# Altium schematic sheet MCU.SchDoc
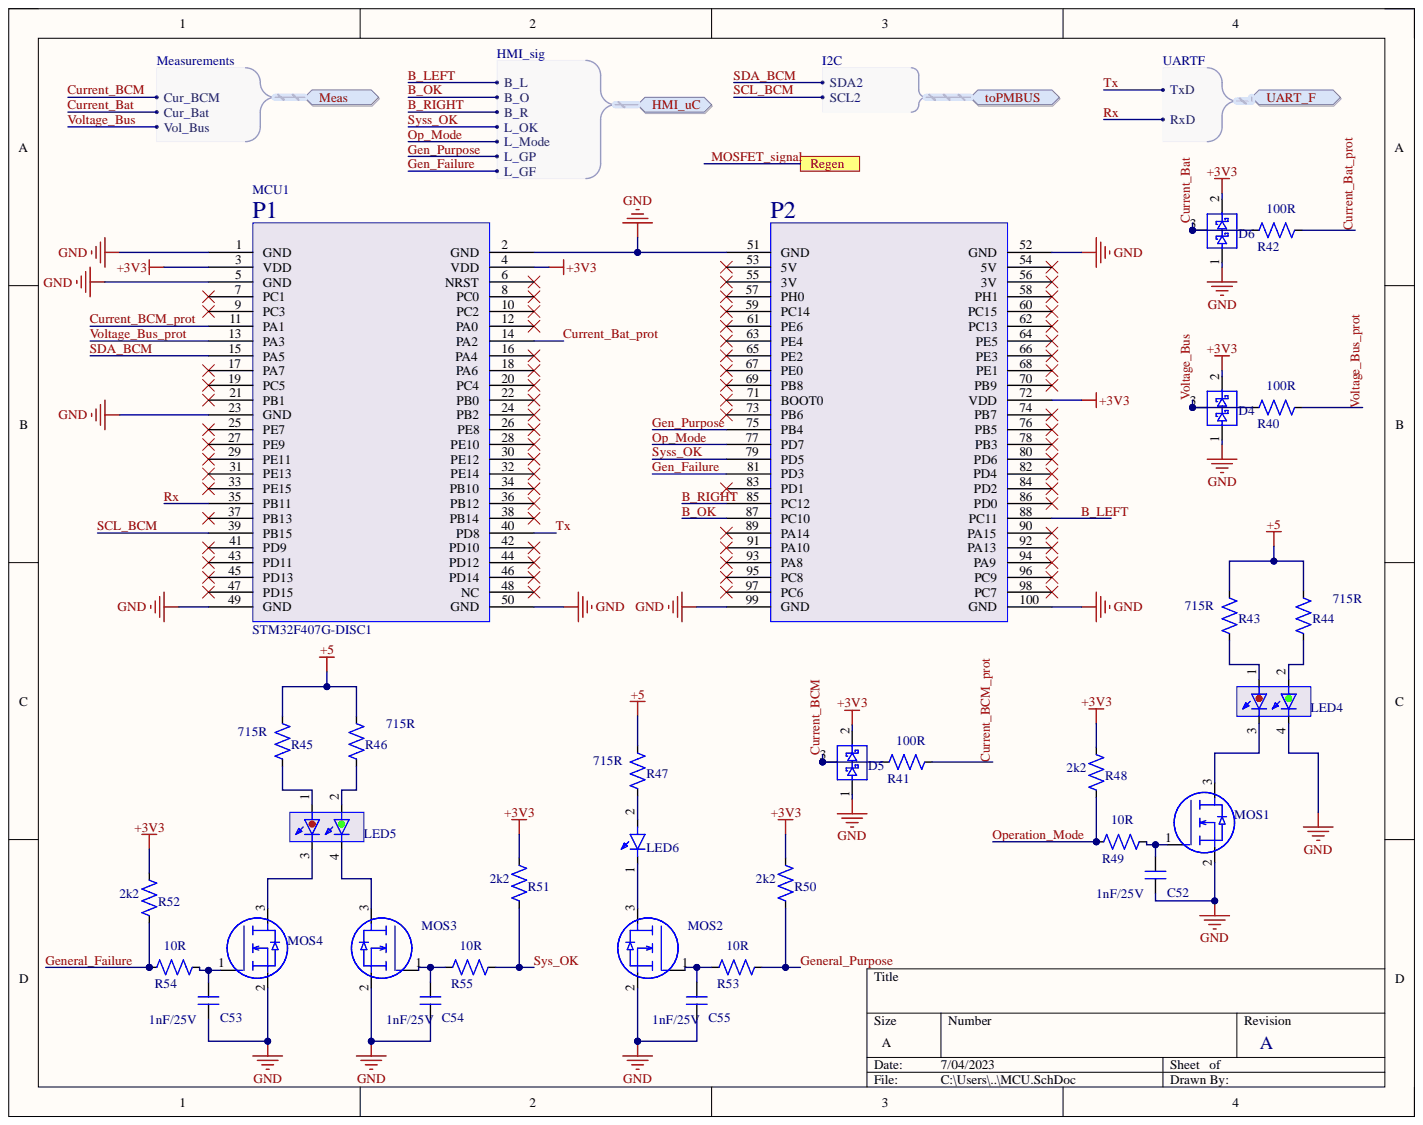
[diagram p0: MCU.SchDoc]

## Parts
C52  1nF/25V  [C0402]
C53  1nF/25V  [C0402]
C54  1nF/25V  [C0402]
C55  1nF/25V  [C0402]
D4  BAT54S  [sot-23-3]  (value not drawn)
D5  BAT54S  [sot-23-3]  (value not drawn)
D6  BAT54S  [sot-23-3]  (value not drawn)
LED4  150141RV73100  [3528_metric]  (value not drawn)
LED5  150141RV73100  [3528_metric]  (value not drawn)
LED6  150080GS75000  [L0805]  (value not drawn)
MCU1  STM32F407G-DISC1  [STM32F407G-DISC1]
MOS1  RK7002BMT116  [SOT23-3-GSD]  (value not drawn)
MOS2  RK7002BMT116  [SOT23-3-GSD]  (value not drawn)
MOS3  RK7002BMT116  [SOT23-3-GSD]  (value not drawn)
MOS4  RK7002BMT116  [SOT23-3-GSD]  (value not drawn)
R40  100R  [R0402]
R41  100R  [R0402]
R42  100R  [R0402]
R43  715R  [R0603]
R44  715R  [R0603]
R45  715R  [R0603]
R46  715R  [R0603]
R47  715R  [R0603]
R48  2k2  [R0402]
R49  10R  [R0402]
R50  2k2  [R0402]
R51  2k2  [R0402]
R52  2k2  [R0402]
R53  10R  [R0402]
R54  10R  [R0402]
R55  10R  [R0402]

## Ports
HMI_uC, HMI_uC.B_L, HMI_uC.B_O, HMI_uC.B_R, HMI_uC.L_GF, HMI_uC.L_GP, HMI_uC.L_Mode, HMI_uC.L_OK, Meas, Meas.Cur_Bat, Meas.Cur_BCM, Meas.Vol_Bus, Regen, toPMBUS, toPMBUS.SCL2, toPMBUS.SDA2, UART_F, UART_F.RxD, UART_F.TxD

## Nets
+3V3: D4.2 D5.2 D6.2 MCU1.3(VDD) MCU1.4 MCU1.72(VDD) R48.2 R50.2 R51.2 R52.2
+5: R43.2 R44.2 R45.2 R46.2 R47.2
GND: C52.2 C53.2 C54.2 C55.2 D4.1 D5.1 D6.1 LED4.4 MCU1.1(GND) MCU1.2 MCU1.5(GND) MCU1.23(GND) MCU1.49(GND) MCU1.50 MCU1.51 MCU1.52(GND) MCU1.99 MCU1.100(GND) MOS1.2 MOS2.2 MOS3.2 MOS4.2
B_LEFT: MCU1.88(PC11) ; port HMI_uC
B_OK: MCU1.87 ; port HMI_uC
B_RIGHT: MCU1.85 ; port HMI_uC
Current_Bat: D6.3 R42.1 ; port Meas
Current_Bat_prot: MCU1.14 R42.2
Current_BCM: D5.3 R41.1 ; port Meas
Current_BCM_prot: MCU1.11(PA1) R41.2
Gen_Failure: MCU1.81 ; port HMI_uC
Gen_Purpose: MCU1.75 ; port HMI_uC
General_Failure: R52.1 R54.1
General_Purpose: R50.1 R53.2
Op_Mode: MCU1.77 ; port HMI_uC
Operation_Mode: R48.1 R49.1
Rx: MCU1.35(PB11) ; port UART_F
SCL_BCM: MCU1.39(PB15) ; port toPMBUS
SDA_BCM: MCU1.15(PA5) ; port toPMBUS
Sys_OK: R51.1 R55.2
Syss_OK: MCU1.79 ; port HMI_uC
Tx: MCU1.40 ; port UART_F
Voltage_Bus: D4.3 R40.1 ; port Meas
Voltage_Bus_prot: MCU1.13(PA3) R40.2
NetC52_1: C52.1 MOS1.1 R49.2
NetC53_1: C53.1 MOS4.1 R54.2
NetC54_1: C54.1 MOS3.1 R55.1
NetC55_1: C55.1 MOS2.1 R53.1
NetLED4_1: LED4.1 R43.1
NetLED4_2: LED4.2 R44.1
NetLED4_3: LED4.3 MOS1.3
NetLED5_1: LED5.1 R45.1
NetLED5_2: LED5.2 R46.1
NetLED5_3: LED5.3 MOS4.3
NetLED5_4: LED5.4 MOS3.3
NetLED6_1: LED6.1 MOS2.3
NetLED6_2: LED6.2 R47.1
MOSFET_signal: ; port Regen

Not connected: MCU1 6 7(PC1) 8 9(PC3) 10 12 16 17(PA7) 18 19(PC5) 20 21(PB1) 22 24 25(PE7) 26 27(PE9) 28 29(PE11) 30 31(PE13) 32 33(PE15) 34 36 37(PB13) 38 41(PD9) 42 43(PD11) 44 45(PD13) 46 47(PD15) 48 53 54(5V) 55 56(3V) 57 58(PH1) 59 60(PC15) 61 62(PC13) 63 64(PE5) 65 66(PE3) 67 68(PE1) 69 70(PB9) 71 73 74(PB7) 76(PB5) 78(PB3) 80(PD6) 82(PD4) 83 84(PD2) 86(PD0) 89 90(PA15) 91 92(PA13) 93 94(PA9) 95 96(PC9) 97 98(PC7)

## Library data of the parts
C52-C55 = AC0402KRX7R8BB102: Cap Ceramic 0.001uF 25V X7R 10% SMD 0402 125°; Manufacturer: Yageo; Capacitance: 1nF; Database Table Name: components; Case Code (Imperial): 0402; Case Code (Metric): 1005; Case/Package: 0402; Category: Ceramic Capacitors; Component Kind: Standard; ComponentLink1Description: http://www.yageo.com/documents/recent/UPY-AC_; ComponentLink1URL: http://www.yageo.com/documents/recent/UPY-AC_; ComponentLink2Description: https://www.yageo.com/upload/media/product/pr; ComponentLink2URL: https://www.yageo.com/upload/media/product/pr; Library Name: Resistors-Capacitors.DbLib; Manufacturer Part Number: AC0402KRX7R8BB102; Max Operating Temperature: 125°C; Min Operating Temperature: -55°C; Mount: Surface Mount; Packaging: Cut Tape; Part Number: AC0402KRX7R8BB102; Pricing 1: 1=0,10000, 10=0,02700, 50=0,02700, 100=0,0220; Ratings: AEC-Q200; RoHS: Yes; RoHS Compliant: Yes; Stock 1: 53012; Supplier 1: Mouser; Supplier Part Number 1: 603-AC402KRX7R8BB102; Tolerance: 10%; Voltage Rating: 25V
LED4 LED5 = 150141RV73100: Led, Green, Red, R 250Mcd, G 60Mcd, 120 , R 2; Manufacturer: Wurth Electronics; Database Table Name: hmi; Case/Package: 3528; Category: LEDs; Component Kind: Standard; Library Name: HMI.DbLib; Manufacturer Part Number: 150141RV73100; Mount: Surface Mount; Part Number: 150141RV73100; Pricing 1: 1=0,34000, 10=0,33600, 50=0,33000, 100=0,2870; RoHS: Yes; Stock 1: 19014; Supplier 1: Mouser; Supplier Part Number 1: 710-150141RV73100
LED6 = 150080GS75000: Led, Green, 520 Nm, 3.2 V, 30 Ma, 450 Mcd Roh; Manufacturer: Wurth Electronics; Database Table Name: hmi; Category: LEDs; Component Kind: Standard; Library Name: HMI.DbLib; Manufacturer Part Number: 150080GS75000; Part Number: 150080GS75000; Pricing 1: 1=0,19000, 10=0,18700, 50=0,17600, 100=0,1510; RoHS: Yes; Stock 1: 29367; Supplier 1: Mouser; Supplier Part Number 1: 710-150080GS75000
MCU1 = STM32F407G-DISC1: STM32F407VGT6 Microcontroller Development Kit; Manufacturer: STMicroelectronics; Core Architecture: ARM; Database Table Name: components; Evaluation Kit: Yes; Number of Bits: 32; Category: Microcontrollers; Component Kind: Standard; ComponentLink1Description: http://www.farnell.com/datasheets/1868813.pdf; ComponentLink1URL: http://www.farnell.com/datasheets/1868813.pdf; ComponentLink2Description: http://www.farnell.com/datasheets/1868813.pdf; ComponentLink2URL: http://www.farnell.com/datasheets/1868813.pdf; ComponentLink3Description: http://www.st.com/content/ccc/resource/techni; ComponentLink3URL: http://www.st.com/content/ccc/resource/techni; Lead Free: Lead Free; Library Name: Microcontrollers.DbLib; Manufacturer Part Number: STM32F407G-DISC1; Part Number: STM32F407G-DISC1; Pricing 1: 1=19,90000 (USD); REACH SVHC: No SVHC; RoHS: Yes; Stock 1: 1; Supplier 1: Digi-Key; Supplier Part Number 1: 497-16287-ND
MOS1-MOS4 = RK7002BMT116: N-Channel 60 V 0.2 W Surface Mount 2.5 V Driv; Manufacturer: Rohm; Approvals: cURus; Continuous Drain Current (ID): 250mA; Current Rating: 2A; Database Table Name: components; DC Cold Resistance: 150mR; Drain to Source Breakdown Voltage: 60V; Drain to Source Resistance: 1.7R; Element Configuration: Single; Fall Time: 28ns; FET Type: 1 N-Channel; Fuse Type: Cartridge, Ceramic; Gate to Source Voltage (Vgs): 20V; Input Capacitance: 15pF; Max Power Dissipation: 200mW; Number of Channels: 1; Power Dissipation: 300W; Rds On Max: 2.4R; Rise Time: 5ns; Threshold Voltage: 2.7V; Turn-Off Delay Time: 18ns; Turn-On Delay Time: 3.5ns; Voltage: 250V; Voltage Rating (AC): 1kV; Case/Package: TO-236-3; Category: MOSFETs; Component Kind: Standard; ComponentLink1Description: http://www.farnell.com/datasheets/2197948.pdf; ComponentLink1URL: http://www.farnell.com/datasheets/2197948.pdf; ComponentLink2Description: http://www.mouser.com/ds/2/348/rk7002bmt116-e; ComponentLink2URL: http://www.mouser.com/ds/2/348/rk7002bmt116-e; ComponentLink3Description: http://www.farnell.com/datasheets/2197948.pdf; ComponentLink3URL: http://www.farnell.com/datasheets/2197948.pdf; ComponentLink4Description: http://www.farnell.com/datasheets/2197948.pdf; ComponentLink4URL: http://www.farnell.com/datasheets/2197948.pdf; ComponentLink5Description: http://rohmfs.rohm.com/en/products/databook/d; ComponentLink5URL: http://rohmfs.rohm.com/en/products/databook/d; ComponentLink6Description: http://rohmfs.rohm.com/en/techdata_basic/tran; ComponentLink6URL: http://rohmfs.rohm.com/en/techdata_basic/tran; ComponentLink7Description: https://media.digikey.com/pdf/Data%20Sheets/B; ComponentLink7URL: https://media.digikey.com/pdf/Data%20Sheets/B; ComponentLink8Description: http://www.mouser.com/ds/2/215/574-741252.pdf; ComponentLink8URL: http://www.mouser.com/ds/2/215/574-741252.pdf; ComponentLink9Description: http://mail.keyelco.com/ENG._DEPT/PDF%20CAT%2; ComponentLink9URL: http://mail.keyelco.com/ENG._DEPT/PDF%20CAT%2; ComponentLink10Description: https://datasheet.ciiva.com/29618/3061295-296; ComponentLink10URL: https://datasheet.ciiva.com/29618/3061295-296; ComponentLink11Description: https://datasheet.ciiva.com/29286/448842-da-0; ComponentLink11URL: https://datasheet.ciiva.com/29286/448842-da-0; ComponentLink12Description: https://datasheet.ciiva.com/29755/csd19535kcs; ComponentLink12URL: https://datasheet.ciiva.com/29755/csd19535kcs; ComponentLink13Description: https://datasheet.ciiva.com/46430/1857241-464; ComponentLink13URL: https://datasheet.ciiva.com/46430/1857241-464; Contact Material: Brass; Contact Plating: Tin; Drain to Source Voltage (Vdss): 60V; Height: 16.51mm; Lead Free: Lead Free; Length: 1.496inch; Library Name: Switches.DbLib; Manufacturer Part Number: RK7002BMT116; Material: Brass,Tin; Max Operating Temperature: 150°C; Min Operating Temperature: -55°C; Mount: Surface Mount; Number of Circuits: 1; Number of Elements: 1; Number of Pins: 3; Package Quantity: 3000; Packaging: Tape and Reel; Part Number: RK7002BMT116; Polarity: N-CHANNEL; Pricing 1: 1=0,21000, 10=0,17800, 50=0,17800, 100=0,0940; Reach SVHC Compliant: Yes; Resistor Type: Ceramic; RoHS: Yes; RoHS Compliant: Yes; Series: NexFET™; Stock 1: 98948; Supplier 1: Mouser; Supplier Part Number 1: 755-RK7002BMT116; Termination: SMD/SMT; Voltage Rating (DC): 1kV; Weight: 0.211644oz; Width: 4.7mm
R40-R42 = RT0402FRE07100RL: Res Thin Film 0402 100 Ohm 1% 0.063W(1/16W) ±; Manufacturer: Yageo; Database Table Name: components; Max Power Dissipation: 63mW; Power Rating: 62.5mW; Temperature Coefficient: 50ppm/°C; Voltage Rating: 50V; Case Code (Imperial): 0402; Case Code (Metric): 1005; Case/Package: 0402; Category: Chip SMD Resistors; Component Kind: Standard; ComponentLink1Description: https://datasheet.ciiva.com/12836/pyu-rt-1-to; ComponentLink1URL: https://datasheet.ciiva.com/12836/pyu-rt-1-to; ComponentLink2Description: https://datasheet.ciiva.com/8887/pyu-rt-1-to-; ComponentLink2URL: https://datasheet.ciiva.com/8887/pyu-rt-1-to-; Construction: Rectangular; Contact Plating: Tin,Matte; Depth: 0.5mm; Features: Moisture Resistant; Height: 0.014inch; Lead Free: Lead Free; Length: 1mm; Library Name: Resistors-Capacitors.DbLib; Manufacturer Part Number: RT0402FRE07100RL; Max Operating Temperature: 125°C; Military Standard: Not; Min Operating Temperature: -55°C; Number of Terminations: 2; Package Quantity: 10000; Packaging: Tape and Reel; Part Number: RT0402FRE07100RL; Pricing 1: 1=0,10000, 10=0,07000, 50=0,07000, 100=0,0290; Radiation Hardening: No; Resistance: 100R; Resistor Type: Thin Film; RoHS: Yes; RoHS Compliant: Yes; Series: RT; Size Code: 0402; Stock 1: 800109; Supplier 1: Mouser; Supplier Part Number 1: 603-RT0402FRE07100RL; Termination: SMD/SMT; Tolerance: 1%; Voltage Rating (DC): 50V; Weight: 2.3E-05oz; Width: 0.02inch
R43-R47 = RT0603FRE07715RL: Res Thin Film 0603 715 Ohm 1% 1/10W ±50ppm/°C; Manufacturer: Yageo; Database Table Name: components; Max Power Dissipation: 100mW; Voltage Rating: 75V; Voltage Rating (DC): 50V; Case Code (Imperial): 0603; Case Code (Metric): 1608; Case/Package: 0603; Category: Chip SMD Resistors; Component Kind: Standard; ComponentLink1Description: https://datasheet.ciiva.com/2559/pyu-rt-1-to-; ComponentLink1URL: https://datasheet.ciiva.com/2559/pyu-rt-1-to-; ComponentLink2Description: https://datasheet.ciiva.com/12702/pyu-rt-1-to; ComponentLink2URL: https://datasheet.ciiva.com/12702/pyu-rt-1-to; Contact Plating: Tin,Matte; Height: 0.022inch; Lead Free: Lead Free; Length: 0.063inch; Library Name: Resistors-Capacitors.DbLib; Manufacturer Part Number: RT0603FRE07715RL; Max Operating Temperature: 125°C; Min Operating Temperature: -55°C; Number of Terminations: 2; Package Quantity: 5000; Packaging: Tape and Reel; Part Number: RT0603FRE07715RL; Power Rating: 100mW; Pricing 1: 1=0,10000, 10=0,05700, 50=0,05700, 100=0,0230; Radiation Hardening: No; Resistance: 715R; Resistor Type: Thin Film; RoHS: Yes; RoHS Compliant: Yes; Series: RT; Stock 1: 8890; Supplier 1: Mouser; Supplier Part Number 1: 603-RT0603FRE07715RL; Temperature Coefficient: 50ppm/°C; Termination: SMD/SMT; Tolerance: 1%; Width: 0.031inch
R48 R50-R52 = RC0402JR-132K2L: Res Thick Film 0402 2.2K Ohm 5% 1/16W ±100ppm; Manufacturer: Yageo; Database Table Name: components; Resistance: 2.2kR; Temperature Coefficient: 100ppm/°C; Case/Package: 0402; Category: Chip SMD Resistors; Component Kind: Standard; ComponentLink1Description: https://datasheet.ciiva.com/8894/pyu-rc0402-5; ComponentLink1URL: https://datasheet.ciiva.com/8894/pyu-rc0402-5; Construction: Rectangular; Contact Plating: Tin,Matte; Depth: 0.5mm; Length: 1mm; Library Name: Resistors-Capacitors.DbLib; Manufacturer Part Number: RC0402JR-132K2L; Max Operating Temperature: 155°C; Military Standard: Not; Min Operating Temperature: -55°C; Packaging: Tape and Reel; Part Number: RC0402JR-132K2L; Pricing 1: 1=0,10000, 10=0,01000, 50=0,01000, 100=0,0040; Radiation Hardening: No; Resistor Type: Thick Film; RoHS: Yes; RoHS Compliant: Yes; Stock 1: 75332; Supplier 1: Mouser; Supplier Part Number 1: 603-RC0402JR-132K2L; Tolerance: 5%
R49 R53-R55 = RT0402FRE0710RL: Res Thin Film 0402 10 Ohm 1% 0.063W(1/16W) ±5; Manufacturer: Yageo; Database Table Name: components; Max Power Dissipation: 63mW; Power Rating: 62.5mW; Temperature Coefficient: 50ppm/°C; Voltage Rating: 50V; Case Code (Imperial): 0402; Case Code (Metric): 1005; Case/Package: 0402; Category: Chip SMD Resistors; Component Kind: Standard; ComponentLink1Description: https://datasheet.ciiva.com/12812/pyu-rt-1-to; ComponentLink1URL: https://datasheet.ciiva.com/12812/pyu-rt-1-to; Construction: Rectangular; Contact Plating: Tin,Matte,Lead; Depth: 0.5mm; Height: 0.3mm; Lead Free: Lead Free; Length: 1mm; Library Name: Resistors-Capacitors.DbLib; Manufacturer Part Number: RT0402FRE0710RL; Max Operating Temperature: 125°C; Military Standard: Not; Min Operating Temperature: -55°C; Number of Terminations: 2; Packaging: Tape and Reel; Part Number: RT0402FRE0710RL; Pricing 1: 1=0,10110, 10=0,08390, 25=0,08320, 100=0,0347; Radiation Hardening: No; Resistance: 10R; Resistor Type: Thin Film; RoHS: Yes; RoHS Compliant: Yes; Series: RT; Stock 1: 9607; Supplier 1: Mouser; Supplier Part Number 1: 603-RT0402FRE0710RL; Termination: SMD/SMT; Tolerance: 1%; Voltage Rating (DC): 50V; Weight: 2.3E-05oz; Width: 0.5mm
D4-D6 = BAT54S: Component Kind: Standard; Library Name: Schlib1.SchLib
